# Altium schematic sheet power.SchDoc
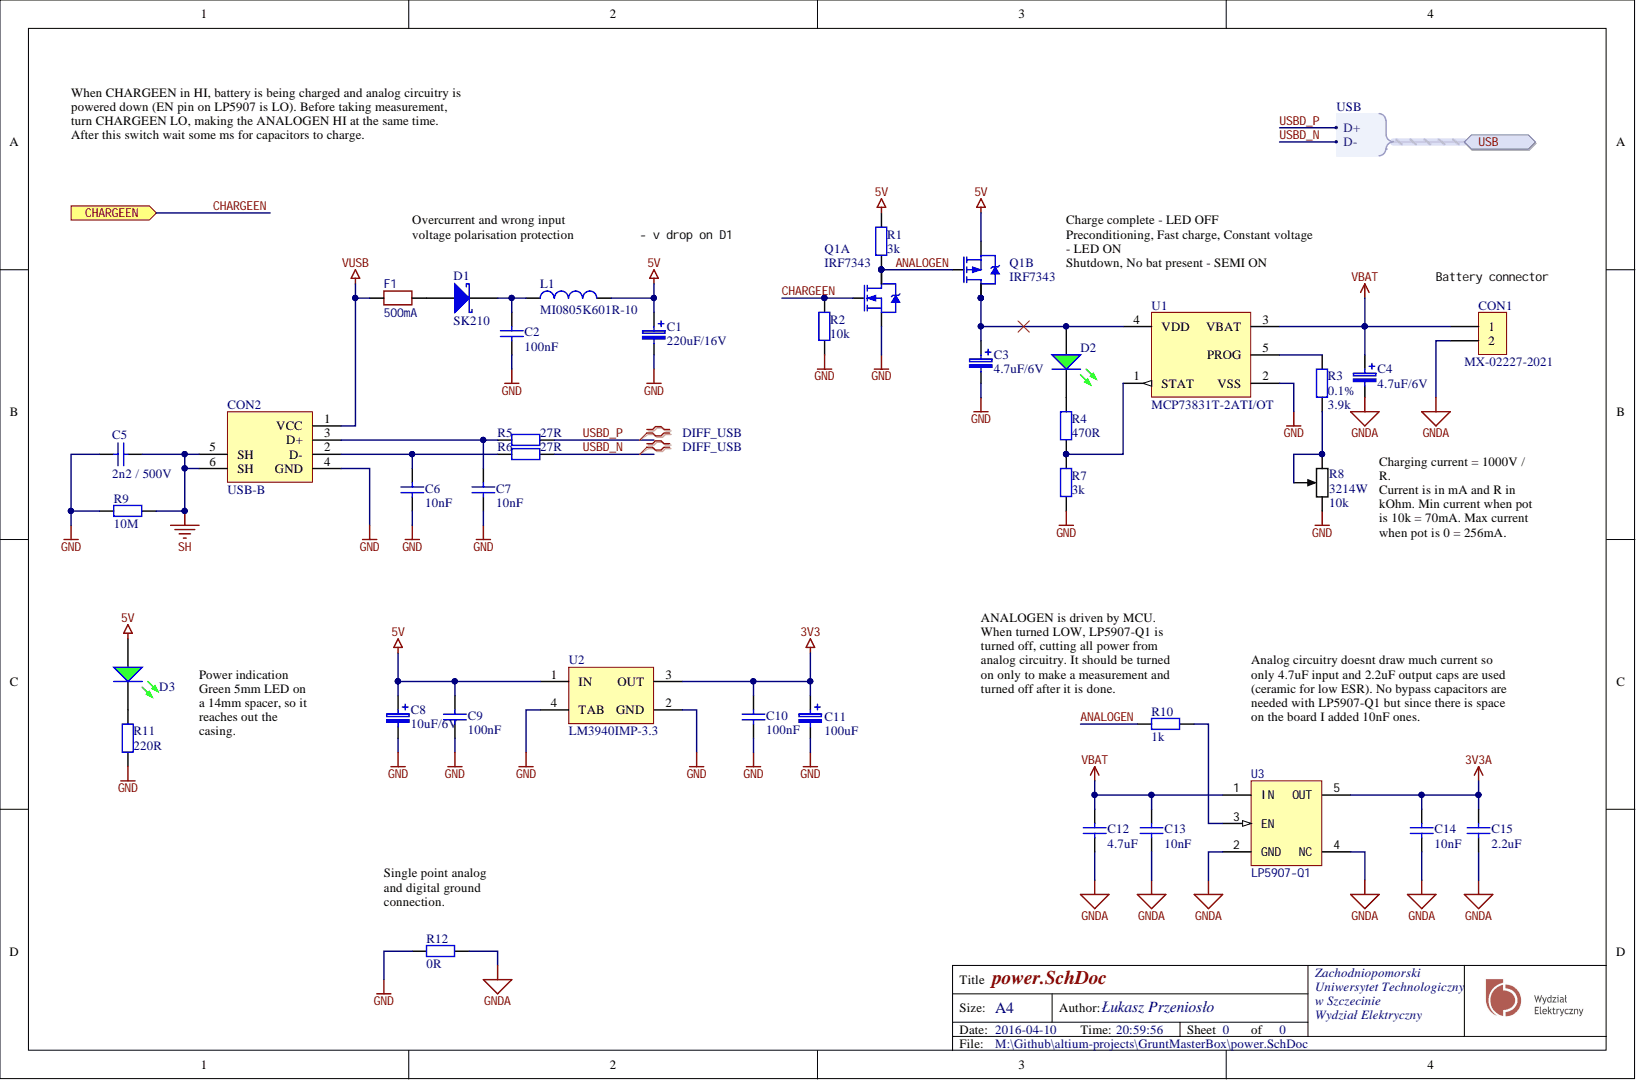
[diagram p0: power.SchDoc]

## Parts
C1  220uF/16V  [CAP_SMD_8.3x6.2]
C2  100nF  [CAPC1608N]
C3  4.7uF/6V  [SMDA]
C4  4.7uF/6V  [SMDA]
C5  2n2 / 500V  [CAPC3216N]
C6  10nF  [CAPC1608N]
C7  10nF  [CAPC1608N]
C8  10uF/6V  [SMDA]
C9  100nF  [CAPC1608N]
C10  100nF  [CAPC1608N]
C11  100uF  [SMDB]
C12  4.7uF  [CAPC1608N]
C13  10nF  [CAPC1608N]
C14  10nF  [CAPC1608N]
C15  2.2uF  [CAPC1608N]
CON1  MX-02227-2021  [MX-7395-02B]
CON2  USB-B  [USB-B_SOCKET]
D1  SK210  [SMB]
D2  LED_GREEN  [PN8923]  (value not drawn)
D3  LED_GREEN  [PN8923]  (value not drawn)
F1  500mA  [RAXXX]
L1  MI0805K601R-10  [FERRITE_1206]
Q1  IRF7343  [SO-8_L]  (parts A,B)
R1  3k  [RESC1608N]
R2  10k  [RESC1608N]
R3  3.9k  Tolerance: 0.1%  [RESC1608N]
R4  470R  [RESC1608N]
R5  27R  [RESC1608N]
R6  27R  [RESC1608N]
R7  3k  [RESC1608N]
R8  3214W  value 10k  [POT3214W]
R9  10M  [RESC3216N]
R10  1k  [RESC1608N]
R11  220R  [RESC1608N]
R12  0R  [RESC3216N]
U1  MCP73831T-2ATI/OT  [SOT23-5_N]
U2  LM3940IMP-3.3  [MP04A_N]
U3  LP5907-Q1  [sot23-5_N]

## Ports
CHARGEEN, USB, USB.D+, USB.D-

## Nets
3V3: C10.2 C11.1 U2.3(OUT)
3V3A: C14.2 C15.2 U3.5(OUT)
5V: C1.1 C8.1 C9.2 D3.1 L1.2 Q1.3 R1.1 U2.1(IN)
GND: C1.2 C2.1 C3.2 C5.2 C6.1 C7.1 C8.2 C9.1 C10.1 C11.2 CON2.4(GND) Q1.1 R2.2 R7.2 R8.3 R9.1 R11.2 R12.1 U1.2(VSS) U2.2(GND) U2.4(TAB)
GNDA: C4.2 C12.1 C13.1 C14.1 C15.1 CON1.2 R12.2 U3.2(GND) U3.4(NC)
SH: C5.1 CON2.5(SH) CON2.6(SH) R9.2
VBAT: C4.1 C12.2 C13.2 CON1.1 U1.3(VBAT) U3.1(IN)
VUSB: CON2.1(VCC) F1.1
ANALOGEN: Q1.4 Q1.7 Q1.8 R1.2 R10.1
CHARGEEN: Q1.2 R2.1 ; port CHARGEEN
USBD_N: R6.1 ; port USB
USBD_P: R5.1 ; port USB
NetC2_2: C2.2 D1.2 L1.1
NetC3_1: C3.1 D2.1 Q1.5 Q1.6 U1.4(VDD)
NetC6_2: C6.2 CON2.2(D-) R6.2
NetC7_2: C7.2 CON2.3(D+) R5.2
NetD1_1: D1.1 F1.2
NetD2_2: D2.2 R4.1
NetD3_2: D3.2 R11.1
NetR3_1: R3.1 U1.5(PROG)
NetR3_2: R3.2 R8.1 R8.2
NetR4_2: R4.2 R7.1 U1.1(STAT)
NetR10_2: R10.2 U3.3(EN)

## Library data of the parts
C1 C3 C4 C8 C11 = Capacitor Tantalum: Component Kind: Standard; Description: Capacitor Tantalum; Library Name: Discrete.SchLib; Signal Integrity: Cap; Simulation: CAP
  C1: Value: 220uF/16V
  C3 C4: Value: 4.7uF/6V
  C8: Value: 10uF/6V
  C11: Value: 100uF
C2 C5-C7 C12-C15 = Capacitor: Component Kind: Standard; Description: Capacitor; Library Name: Discrete.SchLib; Signal Integrity: Cap; Simulation: CAP
  C2: Value: 100nF
  C5: Value: 2n2 / 500V
  C6 C7 C13 C14: Value: 10nF
  C12: Value: 4.7uF
  C15: Value: 2.2uF
C9 C10 = Cap: Capacitor; Component Kind: Standard; LatestRevisionDate: 17-Jul-2002; LatestRevisionNote: Re-released for DXP Platform.; Library Name: Miscellaneous Devices.IntLib; Published: 8-Jun-2000; Signal Integrity: Cap; Simulation: CAP; Publisher: Altium Limited
CON1 = MX-02227-2021: Pith 2.54mm, 2-pin; Component Kind: Standard; ComponentLink1Description: Manufacturer Link; ComponentLink2Description: Datasheet; Distributor: tme.pl; Library Name: Connectors.SchLib; tme.pl: MX-6410-03A
D1 = MBRS340T3: Schottky Barrier Rectifier,  40V, 3A; Component Kind: Standard; PackageReference: SMC; Signal Integrity: D Schottky; Simulation: SKYDIODE; tme.pl: MBRS340T3; Library Name: Mechatronic.SchLib
F1 = RAXXX: Component Kind: Standard; Library Name: my_various_devices.SchLib
L1 = Inductor: Code_IEC: 1005; Value: 82mH; Code_JEITA: 0402; Component Kind: Standard; Description: Inductor; LatestRevisionDate: 29-May-2009; LatestRevisionNote: IPC-7351 Footprint Added.; Library Name: Miscellaneous Devices.IntLib; PackageDescription: Chip Inductor; PackageReference: 0402-A; Published: 8-Jun-2000; Signal Integrity: Inductor; Simulation: INDUCTOR; Publisher: Altium Limited
Q1 = IRF7343: Dual N and P Channel MOSFET; Component Kind: Standard; Library Name: my_various_devices.SchLib
R1 R2 R4-R7 R9-R12 = Resistor: Power: 0W; Tolerance: 5%; Component Kind: Standard; Description: Resistor; Library Name: Discrete.SchLib; Signal Integrity: Res; Simulation: RESISTOR
  R1 R7: Value: 3k
  R2: Value: 10k
  R4: Value: 470R
  R5 R6: Value: 27R
  R9: Value: 10M
  R10: Value: 1k
  R11: Value: 220R
  R12: Value: 0R
R3 = Resistor: Power: 0W; Component Kind: Standard; Description: Resistor; Library Name: Discrete.SchLib; Signal Integrity: Res; Simulation: RESISTOR; Value: 3.9k
R8 = RPot: Potentiometer; Set Position: 0.5; Sim Note: Enter the Resistance value and the set point into parameter fields.; Component Kind: Standard; LatestRevisionDate: 17-Jul-2002; LatestRevisionNote: Re-released for DXP Platform.; PackageDescription: Variable Resistor, Thru-Hole; 3 Leads; PackageReference: VR5; Published: 8-Jun-2000; Simulation: POT; Library Name: my_various_devices.SchLib; Publisher: Altium Limited
U1 = MCP73831-SOT5: Miniature Single-Cell, Fully Integrated Li-Ion, Li-Polymer Charge Management Controller, 4.2V, 5-Pin SOT-23, Industrial Temperature, Tape and Reel; Code_IPC: SOT23-5; Component Kind: Standard; DatasheetVersion: revE, Sep-2008; PackageDescription: 5-Lead Plastic Small Outline Transistor (OT) [SOT-23]; PackageReference: SOT-23-OT5; PackageVersion: revBB, Aug-2009; Library Name: my_various_devices.SchLib
U2 = LM3940IMP-3.3: 1A Low Dropout Regulator for 5V to 3.3V Conversion, 4-pin SOT-223; Manufacturer: National Semiconductor; CompType: LDO IC; PackageTopMarking: XYTT*L52B; PowerWise: N; Code_IPC: SOT223-4; Code_JEDEC: TO-261-AA; Component Kind: Standard; ComponentLink1Description: LM3940 Datasheet; ComponentLink1URL: http://www.national.com/ds/LM/LM3940.pdf; ComponentLink2Description: LM3940 Web Page; ComponentLink2URL: http://www.national.com/pf/LM/LM3940.html; ComponentLink3Description: MP04A Package Page; ComponentLink3URL: http://www.national.com/packaging/folders/mp04a.html; DatasheetVersion: Jul-2007; Library Name: NSC LDO.IntLib; PackageDescription: Molded SOT-223, 6.50 x 3.56 x 1.6mm Body, 4 Lead, 2.3mm Pitch; PackageReference: MP04A; PackageVersion: revB, Apr-2003; PartNumber: LM3940IMP-3.3; PinCount: 4; Published: 4-Dec-2008; RoHS: N; Supplier 1: Farnell; Supplier Part Number 1: 9779280; Publisher: Altium Limited
U3 = LP5907-Q1: Ultra Low-Noise, 250-mA Linear Regulator for RF and Analog Circuits -  Requires No Bypass Capacitor; Component Kind: Standard; Library Name: my_various_devices.SchLib
CON2 = USB-B: Component Kind: Standard; Library Name: my_various_devices.SchLib
D2 D3 = LED_GREEN: Component Kind: Standard; Library Name: Opto.SchLib
